# Altium schematic sheet Motor Driver.SchDoc("Motor Driver")
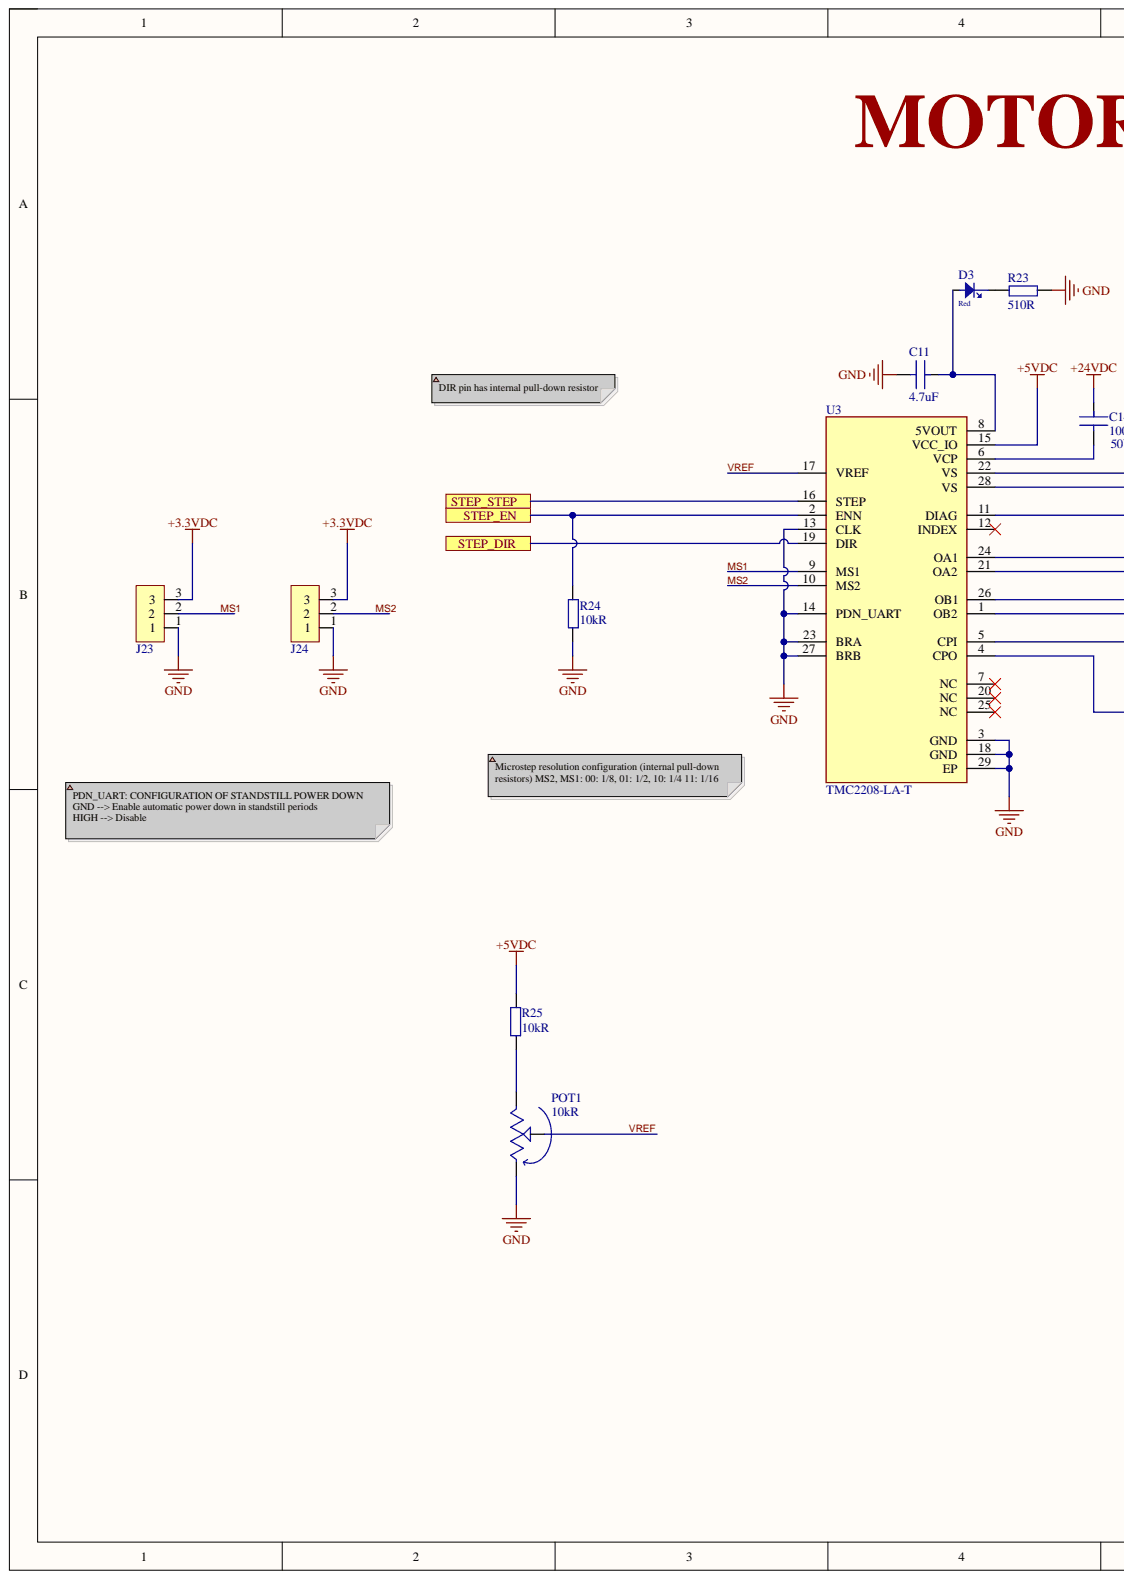
[diagram p0: Motor Driver.SchDoc, left]
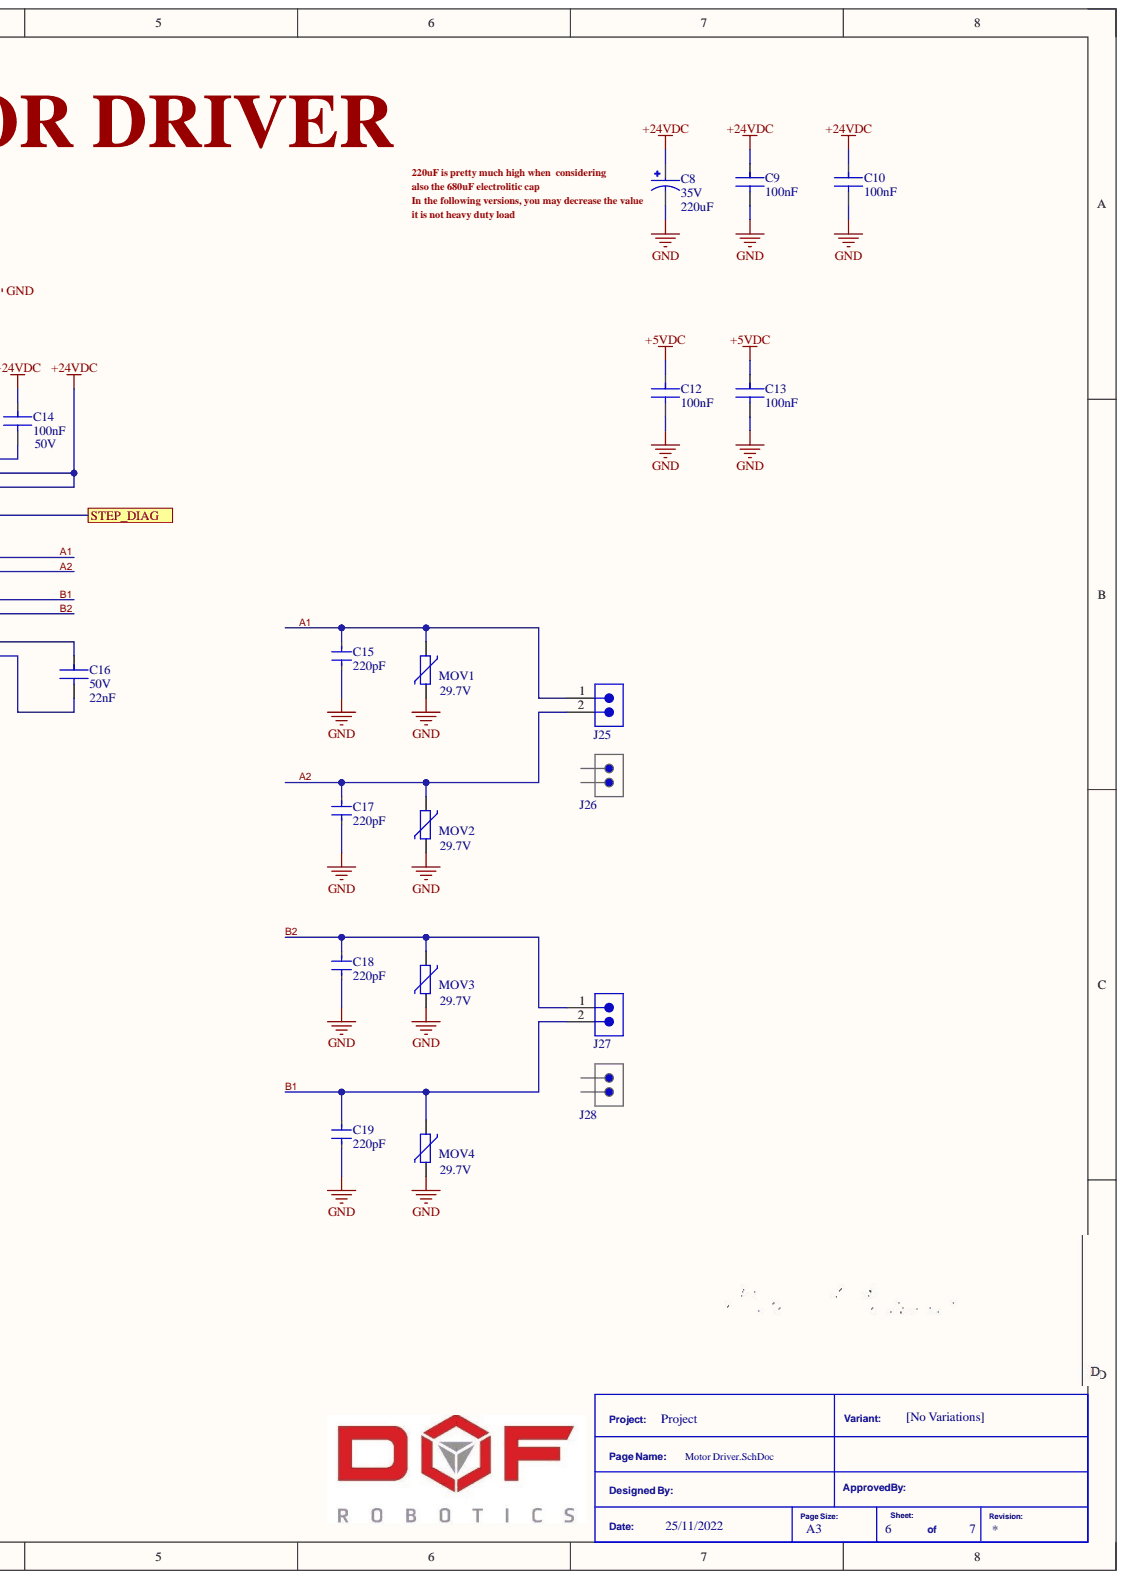
[diagram p1: Motor Driver.SchDoc, right]

## Parts
C8  Capacitance: 220uF  Voltage: 35V  [CAP ALUM SMD 10X10X12]  (hidden comment: MAL214699004E3)
C9  Capacitance: 100nF  [CAP CER 0603]  (hidden comment: C0603C104K5RAC7082)
C10  Capacitance: 100nF  [CAP CER 0603]  (hidden comment: C0603C104K5RAC7082)
C11  Capacitance: 4.7uF  [CAP CER 0603]  (hidden comment: CL10A475KQ8NNNC)
C12  Capacitance: 100nF  [CAP CER 0603]  (hidden comment: C0603C104K5RAC7082)
C13  Capacitance: 100nF  [CAP CER 0603]  (hidden comment: C0603C104K5RAC7082)
C14  Capacitance: 100nF  Voltage Rating (DC): 50V  [CAP CER 0603]  (hidden comment: C0603C104K5RAC7082)
C15  Capacitance: 220pF  [CAP CER 0402]  (hidden comment: C0402C221J2GACAUTO)
C16  Capacitance: 22nF  Voltage Rating: 50V  [CAP CER 0402]  (hidden comment: CL05B223KB5VPNC)
C17  Capacitance: 220pF  [CAP CER 0402]  (hidden comment: C0402C221J2GACAUTO)
C18  Capacitance: 220pF  [CAP CER 0402]  (hidden comment: C0402C221J2GACAUTO)
C19  Capacitance: 220pF  [CAP CER 0402]  (hidden comment: C0402C221J2GACAUTO)
D3  Color: Red  [LED RED 0603]  (hidden comment: 150060RS75000)
J23  61300311121  [HDR_ML_1X3]  (value not drawn)
J24  61300311121  [HDR_ML_1X3]  (value not drawn)
J25  691313710002  [CONN ML 1X2 WURTH 691313710002]  (value not drawn)
J26  691352710002  [CONN FML 1X2 WURTH 691352710002]  (value not drawn)
J27  691313710002  [CONN ML 1X2 WURTH 691313710002]  (value not drawn)
J28  691352710002  [CONN FML 1X2 WURTH 691352710002]  (value not drawn)
MOV1  Min Varistor Voltage: 29.7V  [RES 0805]  (hidden comment: VC0805K121R020)
MOV2  Min Varistor Voltage: 29.7V  [RES 0805]  (hidden comment: VC0805K121R020)
MOV3  Min Varistor Voltage: 29.7V  [RES 0805]  (hidden comment: VC0805K121R020)
MOV4  Min Varistor Voltage: 29.7V  [RES 0805]  (hidden comment: VC0805K121R020)
POT1  Resistance: 10kR  [TC33X-1-103E]  (hidden comment: TC33X-1-103E)
R23  Resistance: 510R  [RES 0603]  (hidden comment: ESR03EZPJ511)
R24  Resistance: 10kR  [RES 0805]  (hidden comment: RNCP0805FTD10K0)
R25  Resistance: 10kR  [RES 0805]  (hidden comment: RNCP0805FTD10K0)
U3  TMC2208-LA-T  [VFQFN-28]

## Ports
STEP_DIAG, STEP_DIR, STEP_EN, STEP_STEP

## Nets
+3.3VDC: J23.3 J24.3
+5VDC: C12.2 C13.2 R25.2 U3.15(VCC_IO)
+24VDC: C8.1 C9.2 C10.2 C14.2 U3.22(VS) U3.28(VS)
GND: C8.2 C9.1 C10.1 C11.1 C12.1 C13.1 C15.1 C17.1 C18.1 C19.1 J23.1 J24.1 MOV1.1 MOV2.1 MOV3.1 MOV4.1 POT1.3 R23.1 R24.2 U3.3(GND) U3.13(CLK) U3.14(PDN_UART) U3.18(GND) U3.23(BRA) U3.27(BRB) U3.29(EP)
A1: C15.2 J25.1 MOV1.2 U3.24(OA1)
A2: C17.2 J25.2 MOV2.2 U3.21(OA2)
B1: C19.2 J27.2 MOV4.2 U3.26(OB1)
B2: C18.2 J27.1 MOV3.2 U3.1(OB2)
MS1: J23.2 U3.9(MS1)
MS2: J24.2 U3.10(MS2)
STEP_DIAG: U3.11(DIAG) ; port STEP_DIAG
STEP_DIR: U3.19(DIR) ; port STEP_DIR
STEP_EN: R24.1 U3.2(ENN) ; port STEP_EN
STEP_STEP: U3.16(STEP) ; port STEP_STEP
VREF: POT1.2 U3.17(VREF)
NetC11_2: C11.2 D3.2 U3.8(5VOUT)
NetC14_1: C14.1 U3.6(VCP)
NetC16_1: C16.1 U3.4(CPO)
NetC16_2: C16.2 U3.5(CPI)
NetD3_1: D3.1 R23.2
NetPOT1_1: POT1.1 R25.1

Not connected: U3 7(NC) 12(INDEX) 20(NC) 25(NC)

## Library data of the parts
C8 = CAP ALUM 220UF 35V SMD 1012: 220uF 35V ALUM Electrolytic Cap 1012; 146 CTI Series 1500 h; 2000 h; 200000 h; Manufacturer: Vishay BCcomponents; Impedance: 97mR; Lead Diameter: 1mm; Leakage Current: 77uA; Life (Hours): 2000Hour; Polarity: Polar; Ripple Current: 900mA; Tolerance: 20%; Case/Package: Radial; Category: Aluminum Electrolytic Capacitors; Component Kind: Standard; ComponentLink1Description: https://datasheet.ciiva.com/99/146cti-99688.pdf?src-supplier=Digi-Key; ComponentLink1URL: https://datasheet.ciiva.com/99/146cti-99688.pdf?src-supplier=Digi-Key; ComponentLink2Description: https://datasheet.ciiva.com/99/146cti-99689.pdf?src-supplier=Digi-Key; ComponentLink2URL: https://datasheet.ciiva.com/99/146cti-99689.pdf?src-supplier=Digi-Key; ComponentLink3Description: https://datasheet.ciiva.com/11777/0900766b81003e87-11777120.pdf?src-supplier=RSComponents; ComponentLink3URL: https://datasheet.ciiva.com/11777/0900766b81003e87-11777120.pdf?src-supplier=RSComponents; ComponentLink4Description: https://datasheet.ciiva.com/99/146cti-99690.pdf?src-supplier=Digi-Key; ComponentLink4URL: https://datasheet.ciiva.com/99/146cti-99690.pdf?src-supplier=Digi-Key; ComponentLink5Description: https://datasheet.ciiva.com/5360/146cti-247382-5360191.pdf?src-supplier=Mouser; ComponentLink5URL: https://datasheet.ciiva.com/5360/146cti-247382-5360191.pdf?src-supplier=Mouser; ComponentLink6Description: https://datasheet.ciiva.com/11697/146cti-11697674.pdf?src-supplier=Avnet; ComponentLink6URL: https://datasheet.ciiva.com/11697/146cti-11697674.pdf?src-supplier=Avnet; Construction: Cylindrical; Diameter: 10mm; Height: 12mm; Height - Seated (Max): 0.472inch; Lead Free: Lead Free; Lead Pitch: 3.5mm; Manufacturer Part Number: MAL214699004E3; Max Operating Temperature: 125°C; Min Operating Temperature: -55°C; Mount: Surface Mount; Package Quantity: 250; Packaging: Tape and Reel; Pricing 1: 1=2.65000, 10=2.14700, 50=1.87400, 100=1.63980 (USD); Radiation Hardening: No; RoHS: Yes; RoHS Compliant: Yes; Series: 146 CTI; Stock 1: 4735; Supplier 1: Digi-Key; Supplier Part Number 1: 4427PHCT-ND; Termination: SMD/SMT; Voltage Rating: 35V; Voltage Rating (DC): 35V; Width: 10mm; Library Name: LibEnes.SchLib
C9 C10 C12-C14 = CAP CER 0.1UF 50V X7R 0603: Cap Cer 0.1uF 50V 0603 10%; Manufacturer: KEMET; DesignedBy: ENES MERCAN; Dielectric: X7R; Dielectric Material: Ceramic; Case/Package: 0603; Category: Ceramic Capacitors; Component Kind: Standard; Library Name: LibEnes.SchLib; Manufacturer Part Number: C0603C104K5RAC7082; Pricing 1: 1=0.12000, 10=0.08900, 50=0.04840, 100=0.03940, 500=0.02818, 1000=0.02214, 2500=0.02012, 5000=0.01852 (USD); RoHS: Yes; RoHS Compliant: Yes; Stock 1: 44787; Supplier 1: Digi-Key; Supplier Part Number 1: 399-C0603C104K5RAC7082CT-ND; Tolerance: 10%; Weight: 0.063
  C9 C10 C12 C13: Voltage Rating (DC): 50V
C11 = CAP CER 4.7UF 6.3V X5R 0603: 4.7uF ±10% 6.3V X5R 0603; Manufacturer: Samsung; Material: Ceramic; Tolerance: 20%; Case Code (Imperial): 0603; Case Code (Metric): 1608; Case/Package: 0603; Category: Ceramic Capacitors; Component Kind: Standard; ComponentLink1Description: https://datasheet.ciiva.com/15195/getdatasheetpartid-358620-15195714.pdf?src-supplier=Verical; ComponentLink1URL: https://datasheet.ciiva.com/15195/getdatasheetpartid-358620-15195714.pdf?src-supplier=Verical; ComponentLink2Description: https://datasheet.ciiva.com/29198/457675-da-01-en-vs-kondensator-0603-29198672.pdf?src-supplier=Conrad; ComponentLink2URL: https://datasheet.ciiva.com/29198/457675-da-01-en-vs-kondensator-0603-29198672.pdf?src-supplier=Conrad; ComponentLink3Description: https://datasheet.ciiva.com/16437/cl05b104kq5nnnc-16437321.pdf?src-supplier=Future+Electronics; ComponentLink3URL: https://datasheet.ciiva.com/16437/cl05b104kq5nnnc-16437321.pdf?src-supplier=Future+Electronics; Construction: Flat; Contact Plating: Tin; Depth: 0.8mm; Dielectric: X5R; Height: 0.8mm; Length: 1.6mm; Manufacturer Part Number: CL10A475KQ8NNNC; Max Operating Temperature: 85°C; Min Operating Temperature: -55°C; Mount: Surface Mount; Package Quantity: 1; Packaging: Tape and Reel; Pricing 1: 1=0.12500, 25=0.05400, 50=0.02900, 100=0.02400, 250=0.02000, 500=0.01600 (USD); Radiation Hardening: No; RoHS: Yes; RoHS Compliant: Yes; Series: CL; Stock 1: 36381; Supplier 1: Newark; Supplier Part Number 1: 82AC9301; Thickness: 0.035inch; Voltage: 6.3V; Voltage Rating: 6.3V; Width: 0.031inch; Library Name: LibEnes.SchLib
C15 C17-C19 = CAP CER 220PF 200V 5% 0402: 0402 C 220pF Ceramic Multilayer Capacitor; 200 V; +125degC; C0G Dielec; +/-5%; Manufacturer: KEMET; Temperature Coefficient: 30ppm/°C; Tolerance: 5%; Voltage: 200V; Case Code (Imperial): 0402; Case Code (Metric): 1005; Case/Package: 0402; Category: Ceramic Capacitors; Component Kind: Standard; ComponentLink1Description: http://uk.rs-online.com/webdocs/1528/0900766b81528c11.pdf?src-supplier=RSComponents; ComponentLink1URL: http://uk.rs-online.com/webdocs/1528/0900766b81528c11.pdf?src-supplier=RSComponents; ComponentLink2Description: http://www.farnell.com/datasheets/2121647.pdf?src-supplier=Farnell; ComponentLink2URL: http://www.farnell.com/datasheets/2121647.pdf?src-supplier=Farnell; ComponentLink3Description: https://api.kemet.com/component-edge/download/datasheet/C0402C221J2GACAUTO.pdf?src-supplier=Digi-Key; ComponentLink3URL: https://api.kemet.com/component-edge/download/datasheet/C0402C221J2GACAUTO.pdf?src-supplier=Digi-Key; ComponentLink4Description: http://www.farnell.com/datasheets/1929048.pdf?src-supplier=Element14; ComponentLink4URL: http://www.farnell.com/datasheets/1929048.pdf?src-supplier=Element14; ComponentLink5Description: http://www.farnell.com/datasheets/2740322.pdf?src-supplier=Newark; ComponentLink5URL: http://www.farnell.com/datasheets/2740322.pdf?src-supplier=Newark; Depth: 0.5mm; Dielectric: C0G; Dielectric Material: Ceramic; Height: 0.5mm; Lead Free: Lead Free; Length: 0.039inch; Manufacturer Part Number: C0402C221J2GACAUTO; Max Operating Temperature: 125°C; Min Operating Temperature: -55°C; Mount: Surface Mount; Packaging: Cut Tape; Pricing 1: 1=0.10000, 10=0.07400, 50=0.04180, 100=0.03470, 500=0.02434, 1000=0.02015, 2500=0.01875, 5000=0.01715 (USD); Ratings: AEC-Q200; REACH SVHC: No SVHC; RoHS: Yes; RoHS Compliant: Yes; Stock 1: 59740; Supplier 1: Digi-Key; Supplier Part Number 1: 399-11552-1-ND; Termination: SMD/SMT; Thickness: 0.022inch; Voltage Rating: 200V; Voltage Rating (DC): 200V; Width: 0.02inch; Library Name: LibEnes.SchLib
C16 = CAP CER 22NF 50V X7R 0402: Cap Ceramic 0.022uF 50V X7R 10% SMD 0402 125°C Paper T/R; Manufacturer: Samsung; Case Code (Imperial): 0402; Case Code (Metric): 1005; Case/Package: 0402; Category: Ceramic Capacitors; Component Kind: Standard; ComponentLink1Description: http://www.samsungsem.com/kr/support/product-search/mlcc/CL05B223KB5VPNC.jsp?src-supplier=Digi-Key; ComponentLink1URL: http://www.samsungsem.com/kr/support/product-search/mlcc/CL05B223KB5VPNC.jsp?src-supplier=Digi-Key; ComponentLink2Description: http://www.samsungsem.com/servlet/FileDownload?type=spec&file=CL05B223KB5VPNC.pdf&src-supplier=Avnet; ComponentLink2URL: http://www.samsungsem.com/servlet/FileDownload?type=spec&file=CL05B223KB5VPNC.pdf&src-supplier=Avnet; Features: Soft Termination; Length: 0.039inch; Manufacturer Part Number: CL05B223KB5VPNC; Max Operating Temperature: 125°C; Min Operating Temperature: -55°C; Mount: Surface Mount; Packaging: Tape and Reel; Pricing 1: 1=0.10000, 10=0.03700, 50=0.02040, 100=0.01660, 500=0.01188, 1000=0.00933, 2500=0.00848, 5000=0.00781 (USD); Ratings: AEC-Q200; RoHS: Yes; RoHS Compliant: Yes; Stock 1: 188259; Supplier 1: Digi-Key; Supplier Part Number 1: 1276-6664-1-ND; Thickness: 0.022inch; Tolerance: 10%; Width: 0.02inch; Library Name: LibEnes.SchLib
D3 = LED RED 0603: WURTH ELEKTRONIK - 150060RS75000 - LED, Red, 625 nm, 2 V, 30 mA, 250 mcd RoHS Compliant: Yes; Manufacturer: Wurth Electronics; Dominant Wavelength: 625nm; Forward Current: 30mA; Forward Voltage: 2V; Lens Style: Clear,Rectangular; Luminous Intensity: 250mcd; Test Current: 20mA; Viewing Angle: 140°; Case Code (Imperial): 0603; Case Code (Metric): 1608; Case/Package: 0603; Category: LEDs; Component Kind: Standard; ComponentLink1Description: https://datasheet.ciiva.com/29563/150060rs75000-29563040.pdf?src-supplier=Digi-Key; ComponentLink1URL: https://datasheet.ciiva.com/29563/150060rs75000-29563040.pdf?src-supplier=Digi-Key; ComponentLink2Description: https://datasheet.ciiva.com/29279/759428-da-01-en-chipled-0603-w-kl-rot-150060rs75000-29279196.pdf?src-supplier=Conrad; ComponentLink2URL: https://datasheet.ciiva.com/29279/759428-da-01-en-chipled-0603-w-kl-rot-150060rs75000-29279196.pdf?src-supplier=Conrad; Height: 0.7mm; Length: 1.6mm; Manufacturer Part Number: 150060RS75000; Max Operating Temperature: 85°C; Min Operating Temperature: -40°C; Mount: Surface Mount; Package Quantity: 1; Packaging: Cut Tape; Pricing 1: 1=0.14000, 50=0.13300, 100=0.12000, 500=0.11400, 1000=0.09900 (USD); REACH SVHC: Unknown; RoHS: Yes; RoHS Compliant: Yes; Stock 1: 253591; Supplier 1: Digi-Key; Supplier Part Number 1: 732-4978-1-ND; Wavelength: 625nm; Weight: 0.0025; Width: 0.8mm; Library Name: LibEnes.SchLib
J23 J24 = HDR ML 1X3 WURTH 61300311121: CONN HEADER 3 POS 2.54; Manufacturer: Wurth Electronics; Connector Type: Male Pin; Gender: Male; Number of Contacts: 3; Pitch: 0.1inch; Category: Connectors; Color: Black; Component Kind: Standard; ComponentLink1Description: http://www.farnell.com/cad/1737268.pdf?src-supplier=Element14; ComponentLink1URL: http://www.farnell.com/cad/1737268.pdf?src-supplier=Element14; ComponentLink2Description: https://katalog.we-online.de/em/datasheet/6130xx11121.pdf?src-supplier=Digi-Key; ComponentLink2URL: https://katalog.we-online.de/em/datasheet/6130xx11121.pdf?src-supplier=Digi-Key; Contact Material: Copper; Contact Mating Length: 0.236inch; Contact Plating: Gold; Lead Free: Lead Free; Manufacturer Part Number: 61300311121; Mount: Through Hole; Number of Positions: 3; Number of Rows: 1; Packaging: Bulk; Pricing 1: 1=0.13000, 10=0.11300, 25=0.09400, 50=0.07900, 100=0.07100, 1000=0.06600 (USD); REACH SVHC: Unknown; RoHS: Yes; RoHS Compliant: Yes; Stock 1: 76429; Supplier 1: Digi-Key; Supplier Part Number 1: 732-5316-ND; Termination: Solder; Library Name: LibEnes.SchLib
J25 J27 = CONN ML 1X2 WURTH 691313710002: 5mm Pitch Right Angle Pluggable Term Block; PCB Header; Through Hole; 2 Way  (Mate to 691352710002); Manufacturer: Wurth Electronics; Connector Type: PCB,Terminal Block; Current: 20A; Number of Contacts: 2; Number of Levels: 1; Pitch: 0.197inch; Voltage: 300V; Category: Terminal Blocks; Color: Green; Component Kind: Standard; ComponentLink1Description: https://datasheet.ciiva.com/795/6913137100xx-795778.pdf?src-supplier=Digi-Key; ComponentLink1URL: https://datasheet.ciiva.com/795/6913137100xx-795778.pdf?src-supplier=Digi-Key; ComponentLink2Description: https://datasheet.ciiva.com/8043/1442229-8043531.pdf?src-supplier=Element14; ComponentLink2URL: https://datasheet.ciiva.com/8043/1442229-8043531.pdf?src-supplier=Element14; ComponentLink3Description: https://datasheet.ciiva.com/48692/001088691-da-01-en-wr-tbl-terminal-block3137-691313710002-48692902.pdf?src-supplier=Conrad; ComponentLink3URL: https://datasheet.ciiva.com/48692/001088691-da-01-en-wr-tbl-terminal-block3137-691313710002-48692902.pdf?src-supplier=Conrad; Contact Material: Brass; Height: 12mm; Length: 12.5mm; Manufacturer Part Number: 691313710002; Mount: Through Hole; Number of Positions Per Level: 2; Number of Rows: 1; Package Quantity: 600; Packaging: Bulk; Pricing 1: 1=0.44000, 10=0.39400, 50=0.34000, 100=0.30500, 250=0.28600, 600=0.26900 (USD); REACH SVHC: Unknown; RoHS: Yes; RoHS Compliant: Yes; Series: WR-TBL Series 3137; Stock 1: 2898; Supplier 1: Digi-Key; Supplier Part Number 1: 732-2799-ND; Termination: Solder; Width: 12mm; Library Name: LibEnes.SchLib
J26 J28 = CONN FML 1X2 WURTH 691352710002: Conn Terminal Block F 2 POS 5mm Screw RA Cable Mount 20A Box    (Mate to 691313710002); Manufacturer: Wurth Electronics; Current Rating: 12A; Number of Contacts: 2; Number of Levels: 1; Pitch: 0.197inch; Stripping Length: -7mm; Voltage: 300V; Wire Gauge (Max): 24AWG; Wire Gauge (Min): 12AWG; Category: Terminal Blocks; Color: Green; Component Kind: Standard; ComponentLink1Description: https://datasheet.ciiva.com/7992/1442233-7992379.pdf?src-supplier=Element14; ComponentLink1URL: https://datasheet.ciiva.com/7992/1442233-7992379.pdf?src-supplier=Element14; ComponentLink2Description: https://datasheet.ciiva.com/796/6913527100xx-796063.pdf?src-supplier=Digi-Key; ComponentLink2URL: https://datasheet.ciiva.com/796/6913527100xx-796063.pdf?src-supplier=Digi-Key; Contact Material: Brass; Current: 20A; Lead Free: Lead Free; Length: 18.2mm; Manufacturer Part Number: 691352710002; Mount: Free Hanging; Number of Positions Per Level: 2; Package Quantity: 350; Packaging: Bulk; REACH SVHC: Unknown; RoHS: Yes; RoHS Compliant: Yes; Series: WR-TBL Series 3527; Stock 1: 2782; Supplier 1: Digi-Key; Supplier Part Number 1: 732-2775-ND; Supplier Unit Price 1: 1=1.32000, 10=1.18200, 50=1.02200, 100=0.91400, 350=0.85900, 700=0.80500 (USD); Termination: Screw; Voltage Rating: 300V; Width: 10mm; Wire/Cable Gauge: 24AWG; Library Name: LibEnes.SchLib
MOV1-MOV4 = VAR 29.7V 36.3V 120A 0805: VC Series SMD 125 °C Low Voltage Multilayer Chip Varistor 0805 120A 26Vdc; Manufacturer: KEMET; Energy: 0.4J; Max Varistor Voltage: 36.3V; Number of Circuits: 1; Varistor Voltage: 33V; Case Code (Imperial): 0805; Case Code (Metric): 2012; Case/Package: 0805; Category: Varistors; Component Kind: Standard; ComponentLink1Description: https://api.kemet.com/component-edge/download/datasheet/VC0805K121R020.pdf?src-supplier=Digi-Key; ComponentLink1URL: https://api.kemet.com/component-edge/download/datasheet/VC0805K121R020.pdf?src-supplier=Digi-Key; Manufacturer Part Number: VC0805K121R020; Max Operating Temperature: 125°C; Max Surge Current: 120A; Min Operating Temperature: -55°C; Mount: Surface Mount; Packaging: Cut Tape; Pricing 1: 1=0.57000, 10=0.39900, 25=0.32760, 100=0.26210, 250=0.19064, 500=0.15488, 1000=0.11914 (USD); RoHS: Yes; RoHS Compliant: Yes; Stock 1: 1179; Supplier 1: Digi-Key; Supplier Part Number 1: 399-13756-1-ND; Library Name: LibEnes.SchLib
POT1 = POT TC33X-1-103E: Res Trimmer 10K Ohm 25% 1/10W 1(Elec)/1(Mech)Turn (3 X 3.8mm) Foot Print SMD Embossed T/R; Manufacturer: Bourns; Max Power Dissipation: 100mW; Temperature Coefficient: 250ppm/°C; Category: Variable Resistors and Potentiometers; Component Kind: Standard; ComponentLink1Description: https://datasheet.ciiva.com/17562/tc33-17562453.pdf?src-supplier=Avnet+Europe; ComponentLink1URL: https://datasheet.ciiva.com/17562/tc33-17562453.pdf?src-supplier=Avnet+Europe; ComponentLink2Description: https://datasheet.ciiva.com/2159/tc33-2159804.pdf?src-supplier=Digi-Key; ComponentLink2URL: https://datasheet.ciiva.com/2159/tc33-2159804.pdf?src-supplier=Digi-Key; Depth: 3.8mm; Height: 0.047inch; Length: 3mm; Manufacturer Part Number: TC33X-1-103E; Max Operating Temperature: 100°C; Military Standard: Not; Min Operating Temperature: -40°C; Mount: Surface Mount; Package Quantity: 2500; Packaging: Tape and Reel; Power Rating: 100mW; Pricing 1: 1=0.26000, 10=0.25500, 25=0.22960, 50=0.21920, 100=0.21210, 250=0.19796, 500=0.18028, 1000=0.16261 (USD); Resistor Type: Cermet; RoHS: Yes; RoHS Compliant: Yes; Series: Trimpot® TC33 - Open Frame; Stock 1: 18163; Supplier 1: Digi-Key; Supplier Part Number 1: TC33X-1-103ECT-ND; Termination: Foot Print; Tolerance: 25%; Width: 0.118inch; Library Name: LibEnes.SchLib
R23 = RES 510R 5% 0.25W 0603: Res Thick Film 0603 510 Ohm 5% 1/4W +/-200ppm/ßC Molded SMD SMD Paper T/R; Manufacturer: Rohm; Max Power Dissipation: 250mW; Temperature Coefficient: 200ppm/°C; Case Code (Imperial): 0603; Case Code (Metric): 1608; Case/Package: 0603; Category: Chip SMD Resistors; Component Kind: Standard; ComponentLink1Description: http://www.rohm.com/web/global/search/parametric/-/search/generateQRCode/dsheet/22/1/40101045/ESR10EZPD?src-supplier=Avnet; ComponentLink1URL: http://www.rohm.com/web/global/search/parametric/-/search/generateQRCode/dsheet/22/1/40101045/ESR10EZPD?src-supplier=Avnet; ComponentLink2Description: https://www.rohm.com/datasheet/ESR01MZPF/esr-e?src-supplier=Digi-Key; ComponentLink2URL: https://www.rohm.com/datasheet/ESR01MZPF/esr-e?src-supplier=Digi-Key; Contact Plating: Tin,Matte; Features: Automotive AEC-Q200; Lead Free: Lead Free; Manufacturer Part Number: ESR03EZPJ511; Max Operating Temperature: 155°C; Min Operating Temperature: -55°C; Number of Terminations: 2; Packaging: Tape and Reel; Pricing 1: 1=0.13000, 10=0.12100, 100=0.04640, 1000=0.02039, 2500=0.01761 (USD); Radiation Hardening: No; Resistor Type: Thick Film; RoHS: Yes; RoHS Compliant: Yes; Series: ESR; Stock 1: 144888; Supplier 1: Digi-Key; Supplier Part Number 1: RHM510DDKR-ND; Tolerance: 5%; Library Name: LibEnes.SchLib
R24 R25 = RES 10K 0805: Res Thin Film 0805 10K Ohm 1% 1/4W ±100ppm/°C Molded SMD Paper T/R; Manufacturer: Stackpole Electronics; Max Power Dissipation: 250mW; Case Code (Imperial): 0805; Case Code (Metric): 2012; Case/Package: 0805; Category: Chip SMD Resistors; Component Kind: Standard; ComponentLink1Description: https://www.seielect.com/catalog/SEI-Packaging.pdf?src-supplier=Digi-Key; ComponentLink1URL: https://www.seielect.com/catalog/SEI-Packaging.pdf?src-supplier=Digi-Key; Features: Moisture Resistant,Anti-Sulfur; Height: 0.024inch; Lead Free: Lead Free; Length: 0.079inch; Manufacturer Part Number: RNCP0805FTD10K0; Max Operating Temperature: 155°C; Min Operating Temperature: -55°C; Mount: Surface Mount; Number of Terminations: 2; Packaging: Tape and Reel; Pricing 1: 1=0.10000, 10=0.07300, 100=0.02970, 1000=0.01336, 2500=0.01159 (USD); Resistor Type: Thin Film; RoHS: Yes; RoHS Compliant: Yes; Series: RNCP; Stock 1: 1114; Supplier 1: Digi-Key; Supplier Part Number 1: RNCP0805FTD10K0CT-ND; Temperature Coefficient: 100ppm/°C; Tolerance: 1%; Width: 0.049inch; Library Name: LibEnes.SchLib
U3 = TMC2208-LA-T: Motor Driver, Stepper, QFN-28; Manufacturer: Trinamic; Interface: UART,Logic; Logic Function: AND GATE; Output Current: 1.2A; Case/Package: QFN; Category: Motor Drivers; Component Kind: Standard; ComponentLink1Description: http://www.farnell.com/datasheets/2551907.pdf?src-supplier=Farnell; ComponentLink1URL: http://www.farnell.com/datasheets/2551907.pdf?src-supplier=Farnell; ComponentLink2Description: https://www.trinamic.com/fileadmin/assets/Products/ICs_Documents/TMC220x_TMC222x_Datasheet.pdf?src-supplier=Digi-Key; ComponentLink2URL: https://www.trinamic.com/fileadmin/assets/Products/ICs_Documents/TMC220x_TMC222x_Datasheet.pdf?src-supplier=Digi-Key; ComponentLink3Description: https://www.trinamic.com/fileadmin/assets/Products/ICs_Documents/TMC220x_TMC2224_datasheet_Rev1.09.pdf?src-supplier=Digi-Key; ComponentLink3URL: https://www.trinamic.com/fileadmin/assets/Products/ICs_Documents/TMC220x_TMC2224_datasheet_Rev1.09.pdf?src-supplier=Digi-Key; Manufacturer Part Number: TMC2208-LA-T; Max Operating Temperature: 125°C; Min Operating Temperature: -40°C; Mount: Surface Mount; Packaging: Tape and Reel; Pricing 1: 1=2.54000, 50=2.27900, 100=2.01400, 500=1.87500 (USD); RoHS: Yes; Stock 1: 16784; Supplier 1: Digi-Key; Supplier Part Number 1: 1460-1184-1-ND; Library Name: LibEnes.SchLib
